# Altium schematic sheet Control Loop.SchDoc
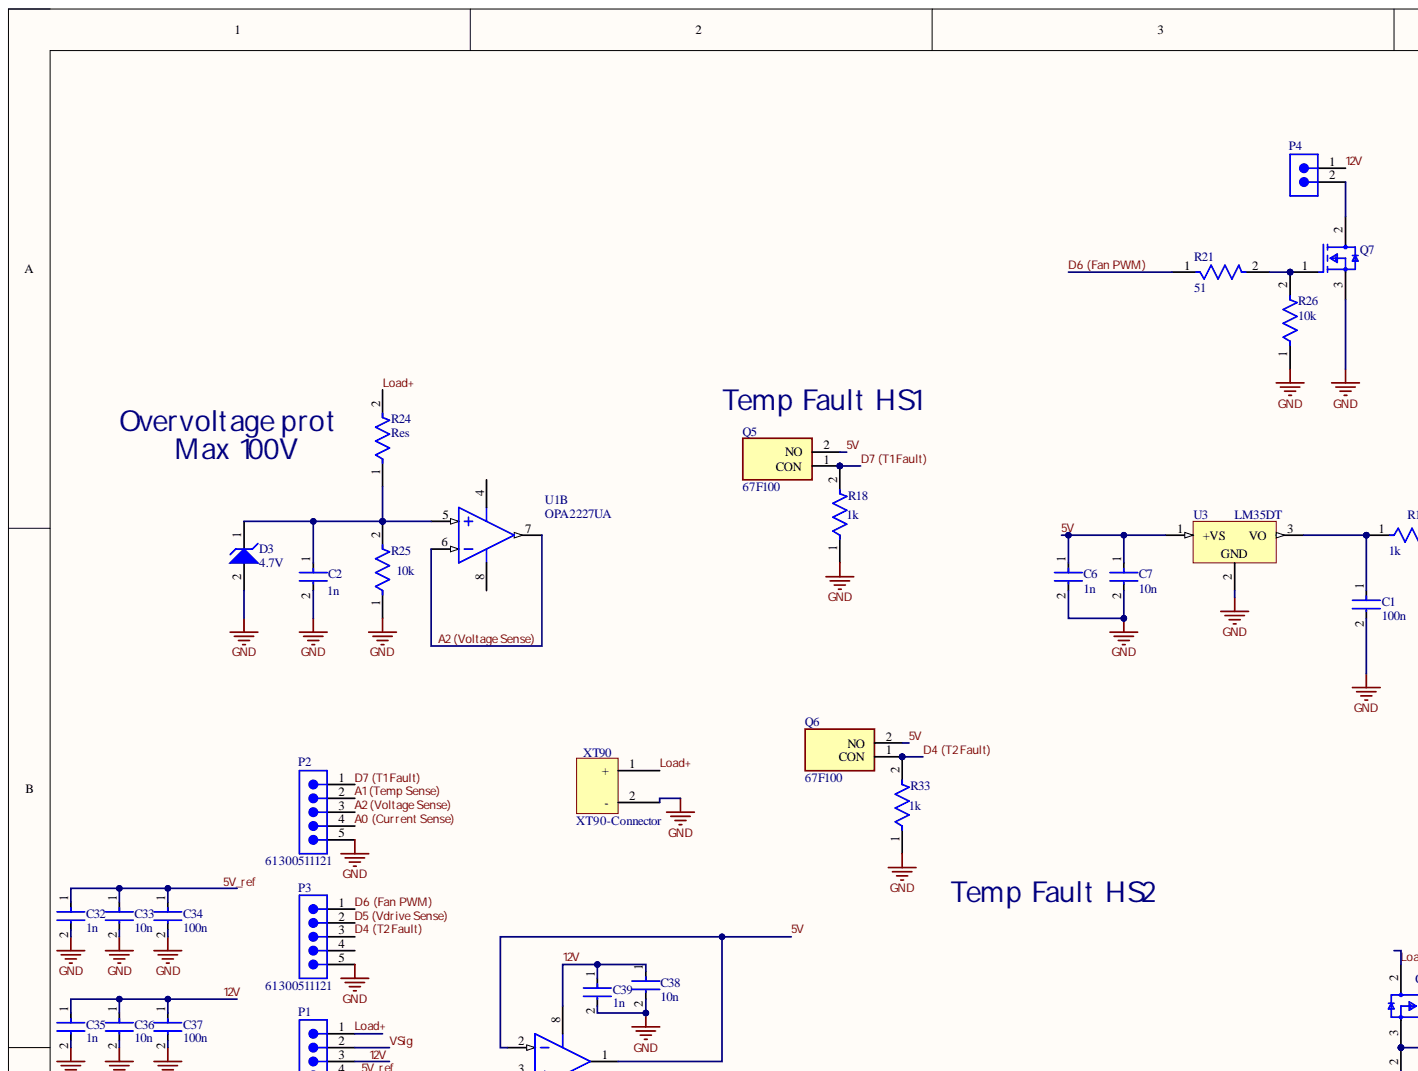
[diagram p0: Control Loop.SchDoc, top left]
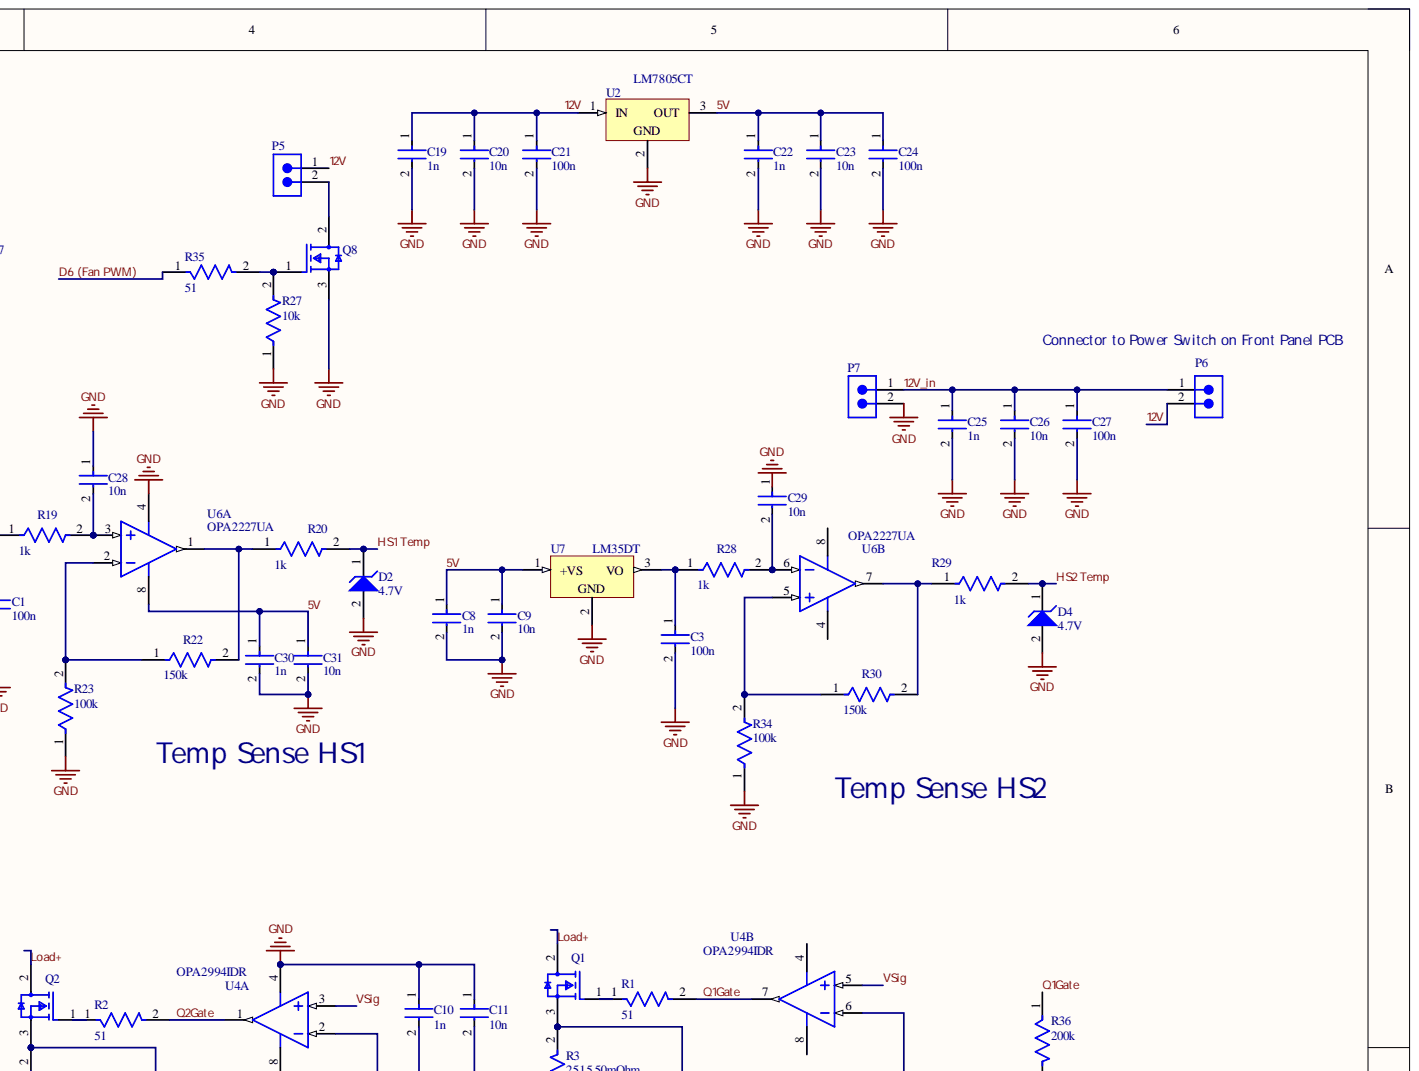
[diagram p1: Control Loop.SchDoc, top right]
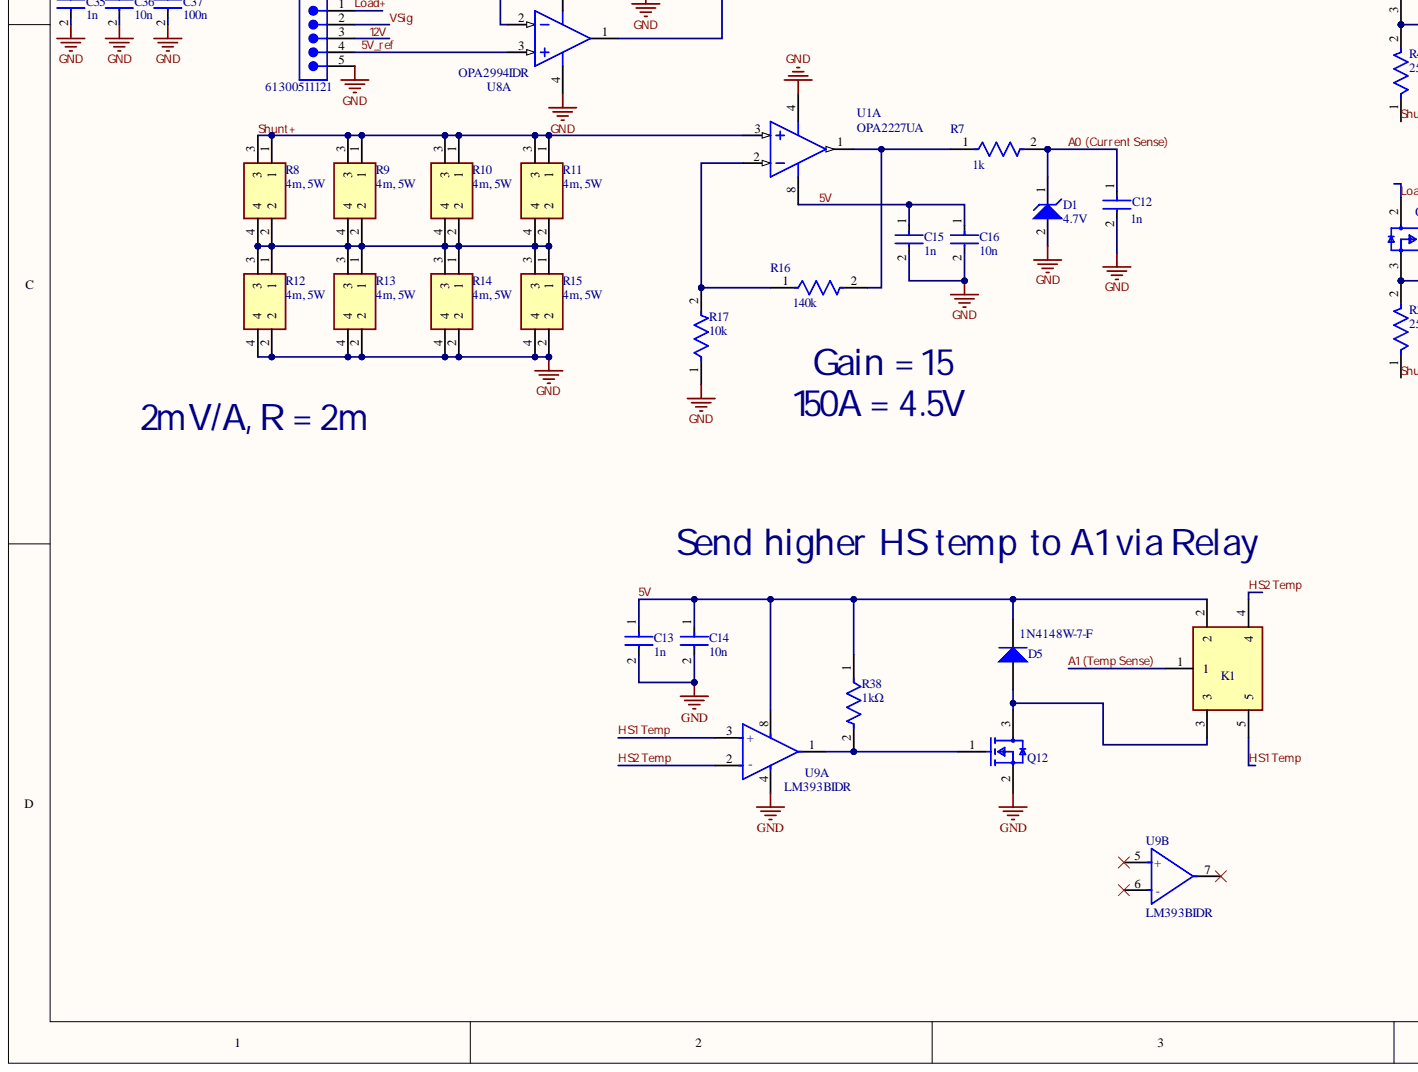
[diagram p2: Control Loop.SchDoc, bottom left]
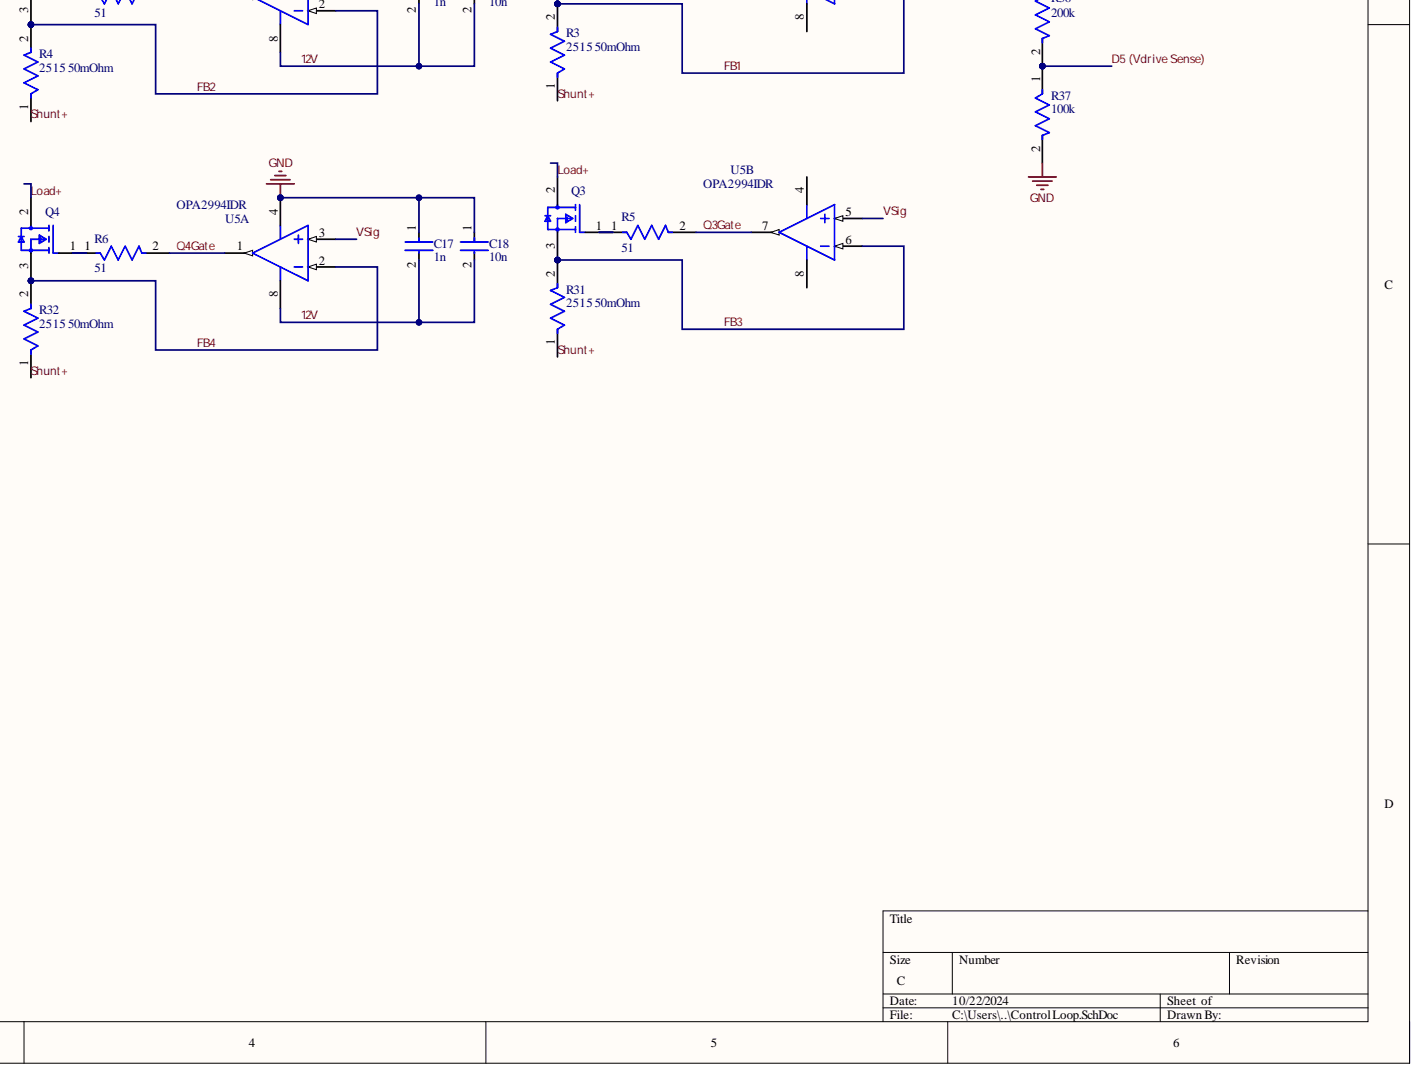
[diagram p3: Control Loop.SchDoc, bottom right]

## Parts
*XT90  XT90-Connector  [XT90-Footprint-1]
C1  100n  [FP-C1206C-EB-MFG]
C2  1n  [FP-C1206C-EB-MFG]
C3  100n  [FP-C1206C-EB-MFG]
C6  1n  [FP-C1206C-EB-MFG]
C7  10n  [FP-C1206C-EB-MFG]
C8  1n  [FP-C1206C-EB-MFG]
C9  10n  [FP-C1206C-EB-MFG]
C10  1n  [FP-C1206C-EB-MFG]
C11  10n  [FP-C1206C-EB-MFG]
C12  1n  [FP-C1206C-EB-MFG]
C13  1n  [FP-C1206C-EB-MFG]
C14  10n  [FP-C1206C-EB-MFG]
C15  1n  [FP-C1206C-EB-MFG]
C16  10n  [FP-C1206C-EB-MFG]
C17  1n  [FP-C1206C-EB-MFG]
C18  10n  [FP-C1206C-EB-MFG]
C19  1n  [FP-C1206C-EB-MFG]
C20  10n  [FP-C1206C-EB-MFG]
C21  100n  [FP-C1206C-EB-MFG]
C22  1n  [FP-C1206C-EB-MFG]
C23  10n  [FP-C1206C-EB-MFG]
C24  100n  [FP-C1206C-EB-MFG]
C25  1n  [FP-C1206C-EB-MFG]
C26  10n  [FP-C1206C-EB-MFG]
C27  100n  [FP-C1206C-EB-MFG]
C28  10n  [FP-C1206C-EB-MFG]
C29  10n  [FP-C1206C-EB-MFG]
C30  1n  [FP-C1206C-EB-MFG]
C31  10n  [FP-C1206C-EB-MFG]
C32  1n  [FP-C1206C-EB-MFG]
C33  10n  [FP-C1206C-EB-MFG]
C34  100n  [FP-C1206C-EB-MFG]
C35  1n  [FP-C1206C-EB-MFG]
C36  10n  [FP-C1206C-EB-MFG]
C37  100n  [FP-C1206C-EB-MFG]
C38  10n  [FP-C1206C-EB-MFG]
C39  1n  [FP-C1206C-EB-MFG]
D1  4.7V  [ONSC-SMA-2-403D-02_F_V]
D2  4.7V  [ONSC-SMA-2-403D-02_F_V]
D3  4.7V  [ONSC-SMA-2-403D-02_F_V]
D4  4.7V  [ONSC-SMA-2-403D-02_F_V]
D5  1N4148W-7-F  [DIOD-SOD123-2_V]
K1  SRD-05VDC-SL-C  [SRD]  (value not drawn)
P1  61300511121  [61300511121]
P2  61300511121  [61300511121]
P3  61300511121  [61300511121]
P4  61300211121  [61300211121]  (value not drawn)
P5  61300211121  [61300211121]  (value not drawn)
P6  61300211121  [61300211121]  (value not drawn)
P7  61300211121  [61300211121]  (value not drawn)
Q1  NVHL027N65S3F  [FP-340CX-MFG]  (value not drawn)
Q2  NVHL027N65S3F  [FP-340CX-MFG]  (value not drawn)
Q3  NVHL027N65S3F  [FP-340CX-MFG]  (value not drawn)
Q4  NVHL027N65S3F  [FP-340CX-MFG]  (value not drawn)
Q5  67F100  [TO508P495X1016X2137-2P]
Q6  67F100  [TO508P495X1016X2137-2P]
Q7  NVHL027N65S3F  [FP-340CX-MFG]  (value not drawn)
Q8  NVHL027N65S3F  [FP-340CX-MFG]  (value not drawn)
Q12  SI2302CDS-T1-E3  [FP-S-03946-MFG]  (value not drawn)
R1  51  [FP-RC1206-MFG]
R2  51  [FP-RC1206-MFG]
R3  2515 50mOhm  [FP-CSRN2512-IPC_A]
R4  2515 50mOhm  [FP-CSRN2512-IPC_A]
R5  51  [FP-RC1206-MFG]
R6  51  [FP-RC1206-MFG]
R7  1k  [FP-RC1206-MFG]
R8  4m, 5W  [WSL27261L000FEA]
R9  4m, 5W  [WSL27261L000FEA]
R10  4m, 5W  [WSL27261L000FEA]
R11  4m, 5W  [WSL27261L000FEA]
R12  4m, 5W  [WSL27261L000FEA]
R13  4m, 5W  [WSL27261L000FEA]
R14  4m, 5W  [WSL27261L000FEA]
R15  4m, 5W  [WSL27261L000FEA]
R16  140k  [FP-RC1206-MFG]
R17  10k  [FP-RC1206-MFG]
R18  1k  [FP-RC1206-MFG]
R19  1k  [FP-RC1206-MFG]
R20  1k  [FP-RC1206-MFG]
R21  51  [FP-RC1206-MFG]
R22  150k  [FP-RC1206-MFG]
R23  100k  [FP-RC1206-MFG]
R24  Res  [FP-RC1206-MFG]
R25  10k  [FP-RC1206-MFG]
R26  10k  [FP-RC1206-MFG]
R27  10k  [FP-RC1206-MFG]
R28  1k  [FP-RC1206-MFG]
R29  1k  [FP-RC1206-MFG]
R30  150k  [FP-RC1206-MFG]
R31  2515 50mOhm  [FP-CSRN2512-IPC_A]
R32  2515 50mOhm  [FP-CSRN2512-IPC_A]
R33  1k  [FP-RC1206-MFG]
R34  100k  [FP-RC1206-MFG]
R35  51  [FP-RC1206-MFG]
R36  200k  [FP-RC1206-MFG]
R37  100k  [FP-RC1206-MFG]
R38  Resistance: 1kΩ  [FP-RT1206-MFG]  (hidden comment: RT1206BRD07150RL)
U1  OPA2227UA  [D0008A_N]  (parts A,B)
U2  LM7805CT  Output Voltage: 5V  [FAIR-TO-220-3]
U3  LM35DT  [NEB0003A]
U4  OPA2994IDR  [D0008A_N]  (parts A,B)
U5  OPA2994IDR  [D0008A_N]  (parts A,B)
U6  OPA2227UA  [D0008A_N]  (parts A,B)
U7  LM35DT  [NEB0003A]
U8  OPA2994IDR  [D0008A_N]  (part A)
U9  LM393BIDR  [FP-D0008A-MFG]  (parts A,B)

## Nets
GND: *XT90.2 C1.2 C2.2 C3.2 C6.2 C7.2 C8.2 C9.2 C10.1 C11.1 C12.2 C13.2 C14.2 C15.2 C16.2 C17.1 C18.1 C19.2 C20.2 C21.2 C22.2 C23.2 C24.2 C25.2 C26.2 C27.2 C28.1 C29.1 C30.2 C31.2 C32.2 C33.2 C34.2 C35.2 C36.2 C37.2 C38.2 C39.2 D1.2 D2.2 D3.2 D4.2 P1.5 P2.5 P3.5 P7.2 Q7.3 Q8.3 Q12.2 R12.2 R12.4 R13.2 R13.4 R14.2 R14.4 R15.2 R15.4 R17.1 R18.1 R23.1 R25.1 R26.1 R27.1 R33.1 R34.1 R37.2 U1.4 U2.2 U3.2 U4.4 U5.4 U6.4 U7.2 U8.4 U9.4
5V: C6.1 C7.1 C8.1 C9.1 C13.1 C14.1 C15.1 C16.1 C22.1 C23.1 C24.1 C30.1 C31.1 D5.2 K1.2 Q5.2 Q6.2 R38.1 U1.8 U2.3 U3.1 U6.8 U7.1 U8.1 U8.2 U9.8
5V_ref: C32.1 C33.1 C34.1 P1.4 U8.3
12V: C10.2 C11.2 C17.2 C18.2 C19.1 C20.1 C21.1 C35.1 C36.1 C37.1 C38.1 C39.1 P1.3 P4.1 P5.1 P6.2 U2.1 U4.8 U5.8 U8.8
12V_in: C25.1 C26.1 C27.1 P6.1 P7.1
A0 (Current Sense): C12.1 D1.1 P2.4 R7.2
A1 (Temp Sense): K1.1 P2.2
A2 (Voltage Sense): P2.3 U1.6 U1.7
D4 (T2 Fault): P3.3 Q6.1 R33.2
D5 (Vdrive Sense): P3.2 R36.2 R37.1
D6 (Fan PWM): P3.1 R21.1 R35.1
D7 (T1 Fault): P2.1 Q5.1 R18.2
FB1: Q1.3 R3.2 U4.6
FB2: Q2.3 R4.2 U4.2
FB3: Q3.3 R31.2 U5.6
FB4: Q4.3 R32.2 U5.2
HS1 Temp: D2.1 K1.5 R20.2 U9.3(+)
HS2 Temp: D4.1 K1.4 R29.2 U9.2(-)
Load+: *XT90.1 P1.1 Q1.2 Q2.2 Q3.2 Q4.2 R24.2
Q1Gate: R1.2 R36.1 U4.7
Q2Gate: R2.2 U4.1
Q3Gate: R5.2 U5.7
Q4Gate: R6.2 U5.1
Shunt+: R3.1 R4.1 R8.1 R8.3 R9.1 R9.3 R10.1 R10.3 R11.1 R11.3 R31.1 R32.1 U1.3
VSig: P1.2 U4.3 U4.5 U5.3 U5.5
NetC1_1: C1.1 R19.1 U3.3
NetC2_1: C2.1 D3.1 R24.1 R25.2 U1.5
NetC3_1: C3.1 R28.1 U7.3
NetC28_2: C28.2 R19.2 U6.3
NetC29_2: C29.2 R28.2 U6.6
NetD5_1: D5.1 K1.3 Q12.3
NetP4_2: P4.2 Q7.2
NetP5_2: P5.2 Q8.2
NetQ1_1: Q1.1 R1.1
NetQ2_1: Q2.1 R2.1
NetQ3_1: Q3.1 R5.1
NetQ4_1: Q4.1 R6.1
NetQ7_1: Q7.1 R21.2 R26.2
NetQ8_1: Q8.1 R27.2 R35.2
NetQ12_1: Q12.1 R38.2 U9.1
NetR7_1: R7.1 R16.2 U1.1
NetR8_2: R8.2 R8.4 R9.2 R9.4 R10.2 R10.4 R11.2 R11.4 R12.1 R12.3 R13.1 R13.3 R14.1 R14.3 R15.1 R15.3
NetR16_1: R16.1 R17.2 U1.2
NetR20_1: R20.1 R22.2 U6.1
NetR22_1: R22.1 R23.2 U6.2
NetR29_1: R29.1 R30.2 U6.7
NetR30_1: R30.1 R34.2 U6.5

Not connected: P3 4; U9 5(+) 6(-) 7

## Library data of the parts
*XT90 = XT90-2: XT90 connector for vertical PCB mounting; Component Kind: Standard; Library Name: Yonder Dynamics
C1-C3 C6-C39 = CMP-03020-000153-1: CAP CER 0.1UF 100V X7R 1206; Manufacturer: KEMET; Manufacturer Part Number: C1206C104K1RACTU; Automotive Grade: Grade 0; Capacitance: 0.10 μF; Material: Ceramic; Temperature Coefficient: 15%; Tolerance: 0.1; Value: 0.10 μF; Automotive: Yes; Category: Cap; Component Kind: Standard; ComponentLink2Description: Datasheet; ComponentLink2URL: https://content.kemet.com/datasheets/KEM_C1007_X8R_ULTRA_150C_SMD.pdf; Device Class L1: Passive Components; Device Class L2: Capacitors; Device Class L3: Ceramic Capacitors; Digikey Description: CAP CER 0.1UF 100V X7R 1206; Height: 0.88mm; Ipc Land Pattern Name: CAPC320160X78; Lead Free: Yes; Library Name: Altium Content Vault; Mouser Description: CAP CER 0.1UF 100V X7R 1206; Octopart: https://octopart.com/c1206c104k1ractu-kemet-89521; Package: C1206C-EB; RoHS: TRUE; Temperature: -55°C to +150°C; Temperature Characteristic: X7R; Temperature Range High: +150°C; Temperature Range Low: -55°C; Voltage Rating: 100 V
D1-D4 = CMP-1060-00128-1: 1.5 W Zener Voltage Regulator, 2-Pin SMA, Pb-Free, Tape and Reel; Manufacturer: On Semiconductor; PartNumber: 1SMA5917BT3G; VZ Typ (V): 4.7; Component Kind: Standard; ComponentLink1Description: Manufacturer URL; ComponentLink1URL: http://www.onsemi.com/; ComponentLink2Description: Datasheet; ComponentLink2URL: http://www.onsemi.com/pub_link/Collateral/1SMA5913BT3-D.PDF; ComponentLink3Description: Package Specification; ComponentLink3URL: http://www.onsemi.com/pub_link/Collateral/1SMA5913BT3-D.PDF; DatasheetVersion: Rev. 8; Library Name: Altium Content Vault; Mounting Technology: Surface Mount; PackageDescription: 2-Pin Small Molded Package, J-Bend Lead, Body 2.605 x 4.315 mm; PackageReference: SMA-2-403D-02_F; PackageVersion: Rev. F, 11/2008; Packing: Tape and Reel; RoHS: Pb-Free
D5 = CMP-2000-04932-2: Fast Switching Diode, 100 V, 0.15 A, -65 to 150 degC, 2-Pin?SOD123, RoHS, Tape and Reel; Brand: DiodesIncorporated; Configuration: Single; If - Forward Current: 0.15A; Ir - Reverse Current: 1uA; Max Surge Current: 2A; Peak Reverse Voltage: 100V; Recovery Time: 4ns; Unit Weight: 0.000353oz; Vf - Forward Voltage: 1.25V; Component Kind: Standard; Datasheet URL: http://www.farnell.com/datasheets/1915529.pdf; Datasheet Version: Rev. 24-2, 10/2013; Factory Pack Quantity: 3000; Height: 1.25mm; Length: 2.85mm; Library Name: Altium Content Vault; Manufacturer: DiodesIncorporated; Manufacturer URL: http://www.diodes.com/; Maximum Operating Temperature: +150C; Minimum Operating Temperature: -65C; Mounting Style: SMD/SMT; Operating Temperature Range: -65Cto+150C; Package / Case: SOD-123; Package Reference: SOD123-2; Package URL: http://www.diodes.com/datasheets/ds18004.pdf; Packaging: Reel; Product: SwitchingDiodes; Product Category: Diodes-GeneralPurpose,Power,Switching; RoHS: True; Series: 1N4148; Type: SwitchingDiode; Width: 1.7mm
K1 = SRD-05VDC-SL-C: Relay or Contactor; Manufacturer_Name: Songle Relay; Component Kind: Standard; Datasheet Link: http://files.microjpm.webnode.com/200001010-dda41de9e1/Songle%20Relay%20Datasheet.pdf; Manufacturer_Part_Number: SRD-05VDC-SL-C
P1-P3 = CMP-1502-01068-3: NexarPartId: 32855526; Connector Type: Male Pin; Contact Resistance: 20mΩ; Current Rating: 3A; Gender: Male; Number of Contacts: 5; Operating Supply Voltage: 250V; Pitch: 2.54mm; Color: Black; Component Kind: Standard; Contact Material: Copper; Contact Mating Length: 5.9944mm; Contact Plating: Gold; Height: 8.89mm; Lead Free: Lead Free; Lead Pitch: 2.54mm; Library Name: Altium Content Vault; Max Operating Temperature: 105°C; Min Operating Temperature: -40°C; Mount: Through Hole; Number of Pins: 5; Number of Positions: 5; Number of Rows: 1; Orientation: Vertical; Packaging: Bulk; REACH SVHC: Unknown; RoHS: Compliant; Termination: Solder; Voltage Rating: 250V
P4-P7 = CMP-1712-00002-6: NexarPartId: 20081794; Connector Type: Male Pin; Contact Resistance: 20mΩ; Current Rating: 3A; Gender: Male; Number of Contacts: 2; Operating Supply Voltage: 250V; Pitch: 2.54mm; Color: Black; Component Kind: Standard; Contact Gender: Male; Contact Material: Copper; Contact Mating Length: 5.9944mm; Contact Plating: Gold; Height: 8.94mm; Housing Material: PBT; Lead Free: Lead Free; Lead Pitch: 2.54mm; Library Name: Altium Content Vault; Max Operating Temperature: 125°C; Min Operating Temperature: -40°C; Mount: Through Hole; Number of Pins: 2; Number of Positions: 2; Number of Rows: 1; Orientation: Vertical; Packaging: Bulk; REACH SVHC: No SVHC; RoHS: Compliant; Termination: Through Hole; Voltage Rating: 250V
Q1-Q4 Q7 Q8 = CMP-00278-97862318-1: NVHL027N65S3F Single N-Channel Power MOSFET SUPERFET® III, FRFET®, 650 V , 75 A, 27.4 mΩ, TO-247; Manufacturer Lifecycle Status: ACTIVE; Continuous Drain Current (ID): 75A; Drain to Source Breakdown Voltage: 650V; Drain to Source Resistance: 21.5mΩ; Gate to Source Voltage (Vgs): 30V; Max Junction Temperature (Tj): 150°C; Number of Channels: 1; Power Dissipation: 595W; Turn-Off Delay Time: 147ns; Turn-On Delay Time: 46ns; Component Kind: Standard; Drain to Source Voltage (Vdss): 650V; Height: 24.75mm; Library Name: Altium Content Vault; Lifecycle Status: Production; Max Operating Temperature: 150°C; Min Operating Temperature: -55°C; NexarPartId: 97862318; RoHS: Compliant; Schedule B: 8541290080, 8541290080
Q5 Q6 = 67F100: Transistor; Manufacturer_Name: Sensata; Component Kind: Standard; Datasheet Link: https://4donline.ihs.com/images/VipMasterIC/IC/AIRP/AIRPS00578/AIRPS00578-1.pdf?hkey=52A5661711E402568146F3353EA87419; Height: 4.95mm; Manufacturer_Part_Number: 67F100; Mouser Part Number: 874-67F100; Mouser Price/Stock: https://www.mouser.co.uk/ProductDetail/AIRPAX/67F100?qs=FESYatJ8odKZ%252BC%252BkzMBILw%3D%3D
Q12 = CMP-02550-000184-1: MOSFET N-CH 20V 2.6A SOT-23; Manufacturer: Vishay; Depletion Mode: False; Drain To Source Resistance: 0.045Ω; Gate Charge At Vgs: 3.5nC @ 4.5V; Gate To Source Voltage: 8V; Max Forward Diode Voltage: 1.2V; Max Junction Temp: +150°C; Number Of Channels: 1; Power Dissipation: 0.71W; Pulse Drain Current: 10A; Reverse Recovery Charge: 2nC; Reverse Recovery Time: 8.5ns; Rthja Max: 140°C/W; Standoff Height: 0.01mm; Threshold Vgs Max: 0.85V; Threshold Vgs Min: 0.4V; Transconductance: 13S; Turn Off Delay Time: 30ns; Turn On Delay Time: 8ns; Typ Forward Diode Voltage: 0.7V; Automotive: No; Category: Trans; Component Kind: Standard; ComponentLink2Description: Datasheet; ComponentLink2URL: http://www.vishay.com/docs/68645/si2302cds.pdf; Continuous Drain Current: 2.6A; Device Class L1: Discrete Semiconductors; Device Class L2: Transistors; Device Class L3: MOSFETs; Digikey Description: MOSFET N-CH 20V 2.6A SOT-23; Drain To Source Breakdown Voltage: 20V; Drain To Source Voltage: 20V; Height: 1.12mm; Lead Free: Yes; Library Name: Altium Content Vault; Manufacturer Part Number: SI2302CDS-T1-E3; Mouser Description: MOSFET 20V 2.9A 0.86W 57mohm @ 4.5V; Number Of N Channels: 1; Octopart: https://octopart.com/si2302cds-t1-e3-vishay-44452855; Package: S-03946; RoHS: TRUE; Temperature: -55°C to +150°C; Temperature Range High: +150°C; Temperature Range Low: -55°C
R1 R2 R5-R7 R16-R30 R33-R37 = CMP-2100-03681-2: RES SMD 0 OHM JUMPER 1/4W 1206; Manufacturer: YAGEO; Manufacturer Part Number: RC1206JR-070RL; Power Rating: 0.25W; Resistance: 0Ω; Temperature Coefficient: 300ppm/°C; Tolerance: 5%; Value: 0Ω; Voltage Rating: 200V; Automotive: No; Category: Res; Component Kind: Standard; ComponentLink2Description: Datasheet; ComponentLink2URL: https://www.yageo.com/upload/media/product/productsearch/datasheet/rchip/PYu-RC_Group_51_RoHS_L_10.pdf; Composition: Thick Film; Device Class L1: Passive Components; Device Class L2: Resistors; Device Class L3: Chip SMD Resistors; Digikey Description: RES SMD 0 OHM JUMPER 1/4W 1206; Footprint Url: https://www.yageo.com/upload/website/yageo_PYu-R_Mount_10_19050818_343.pdf; Height: 0.65mm; Ipc Land Pattern Name: RESC310160X55; Lead Free: Yes; Library Name: Altium Content Vault; Mouser Description: Resistor: thick film; SMD; 1206; 0O; 0.25W; ±5%; -55÷155°C; Octopart: https://octopart.com/rc1206jr-070rl-yageo-40025601; Package: RC1206; RoHS: TRUE; Series: RC; Temperature: -55°C to +155°C; Temperature Range High: +155°C; Temperature Range Low: -55°C
R3 R4 R31 R32 = CMP-26527-006831-1: RCWE251250L0FKEA; Max Power Dissipation: 2W; Resistance: 500mR; Temperature Coefficient: 200ppm/°C; Tolerance: 1%; Case Code (Imperial): 2512; Case Code (Metric): 6432; Case/Package: 2512; Component Kind: Standard; Construction: Rectangular; Contact Plating: Tin; Depth: 3.15mm; Height: 0.028inch; Lead Free: Lead Free; Length: 6.35mm; Library Name: Altium Content Vault; Max Operating Temperature: 155°C; Military Standard: Not; Min Operating Temperature: -55°C; Mount: Surface Mount; Number of Terminations: 2; Packaging: Tape and Reel; Power Rating: 2W; Radiation Hardening: No; Resistor Type: Thick Film; RoHS Compliant: Yes; Series: CSRN; Size Code: 2512; Width: 0.124inch
R8-R15 = WSLP2726L7000FEA: Resistor; Manufacturer_Name: Vishay; Component Kind: Standard; Datasheet Link: https://www.vishay.com/docs/30179/wslp2726.pdf; Height: 2mm; Manufacturer_Part_Number: WSLP2726L7000FEA; Mouser Part Number: 71-WSLP2726L7000FEA; Mouser Price/Stock: https://www.mouser.co.uk/ProductDetail/Vishay-Dale/WSLP2726L7000FEA?qs=Rmyl20M3OaDORiepuTRopg%3D%3D
R38 = CMP-2003-04280-2: RES SMD 150 OHM 0.1% 1/4W 1206; Manufacturer: Yageo; Power Rating: 0.25W; Temperature Coefficient: 25 ppm/°C; Tolerance: 0.005; Value: 150Ω; Voltage Rating: 200V; Automotive: No; Category: Res; Component Kind: Standard; ComponentLink2Description: Datasheet; ComponentLink2URL: http://www.yageo.com/documents/recent/PYu-RT_1-to-0.01_RoHS_L_9.pdf; Composition: Thin Film; Device Class L1: Passive Components; Device Class L2: Resistors; Device Class L3: Chip SMD Resistors; Digikey Description: RES SMD 150 OHM 0.1% 1/4W 1206; Footprint Url: http://www.yageo.com/exep/pages/download/literatures/PYu-R_Mount_10.pdf; Height: 0.65mm; Ipc Land Pattern Name: RESC310160X55; Lead Free: Yes; Library Name: Altium Content Vault; Manufacturer Part Number: RT1206BRD07150RL; Mouser Description: Res Thin Film 1206 150 Ohm 0.1% 1/4W ±25ppm/°C Molded SMD Paper T/R; Octopart: https://octopart.com/rt1206brd07150rl-yageo-1430859; Package: RT1206; RoHS: TRUE; Series: RT; Temperature: -55°C to +155°C; Temperature Range High: +155°C; Temperature Range Low: -55°C
U1 U6 = CMP-0272-00265-3: High Precision, Low Noise Operational Amplifier, 5 to 36 V, -40 to 85 degC, 8-pin SOIC (D8), Green (RoHS & no Sb/Br); Manufacturer: Texas Instruments; CMRR(Min)(dB): 120; GBW(Typ)(MHz): 8; IIB(Max)(pA): 10000; Iq per channel(Max)(mA): 3.8; Number of Channels: 2; Offset Drift(Typ)(uV/C): 0.3; Packing: TUBE; Rating: Catalog; Slew Rate(Typ)(V/us): 2.3; Sub Family: High Supply Voltage Amplifier (>=30V), Low Noise Amp (<=10nV/rtHz), Low Offset Voltage Amplifier (<=500uV), Precision Amplifier; Total Supply Voltage(Max)(+5V is 5, +/-5V is 10): 36; Total Supply Voltage(Min)(+5V is 5, +/-5V is 10): 5; Vio (25C)(Max)(mV): 0.2; Code_JEDEC: MS-012; Component Kind: Standard; ComponentLink1Description: Manufacturer URL; ComponentLink1URL: http://www.ti.com/; ComponentLink2Description: Datasheet; ComponentLink2URL: http://www.ti.com/lit/ds/symlink/opa2227.pdf; ComponentLink3Description: Package Specification; ComponentLink3URL: http://www.ti.com/litv/pdf/msoi002j; DatasheetVersion: SBOS110A; Eco-Plan: Green (RoHS & no Sb/Br); Library Name: Altium Content Vault; Mounting Technology: Surface Mount; PackageDescription: 8-Pin Plastic Small Outline, Body 4.9 x 3.9 mm, Pitch 1.27 mm; PackageReference: D0008A; PackageVersion: 4040047-3/M, 06/2011; PartNumber: OPA2227UA
U2 = CMP-2000-04938-1: Positive Voltage Regulator, 5 V, 1 A, -40 to 125 degC, 3-Pin TO-220, RoHS, Tube; Manufacturer: FairchildSemiconductor; Accuracy: ±4%; Line Regulation: 100mV; Maximum Input Voltage: 35V; Maximum Output Current: 2.2(Typ)A; Maximum Quiescent Current: 8mA; Number of Outputs: 1; Output Type: Fixed; Rad Hard: No; Typical Dropout Voltage @ Current: 2@1AV; Category: LinearRegulator; Component Kind: Standard; Datasheet URL: https://datasheet.ciiva.com/26904/lm7805ct-26904988.pdf; Datasheet Version: Aug-12; Library Name: Altium Content Vault; Load Regulation: 100mV; Manufacturer URL: https://www.fairchildsemi.com/; Mounting: ThroughHole; Operating Temperature: -40to125degC; Package: 3TO-220AB; Package Description: 3-Pin Through Hole Device, Body 10 x 4.5 mm, Pitch 2.54 mm; Package Reference: TO-220; Package URL: https://www.fairchildsemi.com/package-drawings/TO/TO220B03.pdf; Packaging: Rail/Tube; Polarity: Positive; Type: Linear
U3 U7 = CMP-0066-00347-4: Precision Centigrade Temperature Sensor, 3-pin TO-220; Manufacturer: Texas Instruments; CompType: Temp Sensor IC; PackageTopMarking: NSUZXYTTE#*LM35DT; PowerWise: N; Code_JEDEC: TO-220-AB; Component Kind: Standard; ComponentLink1Description: Datasheet URL; ComponentLink1URL: http://www.ti.com/general/docs/lit/getliterature.tsp?genericPartNumber=LM35&fileType=pdf; Library Name: Altium Content Vault; PackageDescription: TO-220, Molded, 3 Lead, Auto L/F; PackageReference: NEB0003A; PinCount: 3; RoHS: N
U4 U5 U8 = CMP-0272-00265-3: High Precision, Low Noise Operational Amplifier, 5 to 36 V, -40 to 85 degC, 8-pin SOIC (D8), Green (RoHS & no Sb/Br); Manufacturer: Texas Instruments; PartNumber: OPA2227UA; CMRR(Min)(dB): 120; GBW(Typ)(MHz): 8; IIB(Max)(pA): 10000; Iq per channel(Max)(mA): 3.8; Number of Channels: 2; Offset Drift(Typ)(uV/C): 0.3; Packing: TUBE; Rating: Catalog; Slew Rate(Typ)(V/us): 2.3; Sub Family: High Supply Voltage Amplifier (>=30V), Low Noise Amp (<=10nV/rtHz), Low Offset Voltage Amplifier (<=500uV), Precision Amplifier; Total Supply Voltage(Max)(+5V is 5, +/-5V is 10): 36; Total Supply Voltage(Min)(+5V is 5, +/-5V is 10): 5; Vio (25C)(Max)(mV): 0.2; Code_JEDEC: MS-012; Component Kind: Standard; ComponentLink1Description: Manufacturer URL; ComponentLink1URL: http://www.ti.com/; ComponentLink2Description: Datasheet; ComponentLink2URL: http://www.ti.com/lit/ds/symlink/opa2227.pdf; ComponentLink3Description: Package Specification; ComponentLink3URL: http://www.ti.com/litv/pdf/msoi002j; DatasheetVersion: SBOS110A; Eco-Plan: Green (RoHS & no Sb/Br); Library Name: Altium Content Vault; Mounting Technology: Surface Mount; PackageDescription: 8-Pin Plastic Small Outline, Body 4.9 x 3.9 mm, Pitch 1.27 mm; PackageReference: D0008A; PackageVersion: 4040047-3/M, 06/2011
U9 = CMP-04896-000018-1: 36V JI.3 DUAL COMPARATOR; Manufacturer: Texas Instruments; Input Bias Current: 0.0035uA; Input Offset Voltage: 0.37mV; Max Junction Temp: +150°C; Min Supply Voltage: 3V; Nominal Supply Current: 400-550uA; Number Of Channels: 2; Output Current Per Channel: 21mA; Response Time: 1us; Standoff Height: 0.11mm; Ambient Temperature Range High: +85°C; Ambient Temperature Range Low: -40°C; Automotive: No; Category: IC; Component Kind: Standard; ComponentLink2Description: Datasheet; ComponentLink2URL: http://www.ti.com/lit/ds/symlink/lm2903b.pdf; Device Class L1: Integrated Circuits (ICs); Device Class L2: Linear ICs; Device Class L3: Comparators; Digikey Description: 36V JI.3 DUAL COMPARATOR; Height: 1.75mm; Lead Free: Yes; Library Name: Altium Content Vault; Manufacturer Part Number: LM393BIDR; Max Supply Voltage: 36V; Octopart: https://octopart.com/lm393bidr-texas+instruments-104977166; Package: D0008A; RoHS: TRUE; Temperature: -40°C to +85°C; Temperature Range High: +85°C; Temperature Range Low: -40°C
